# Altium schematic sheet Peripherals.SchDoc(Peripherals)
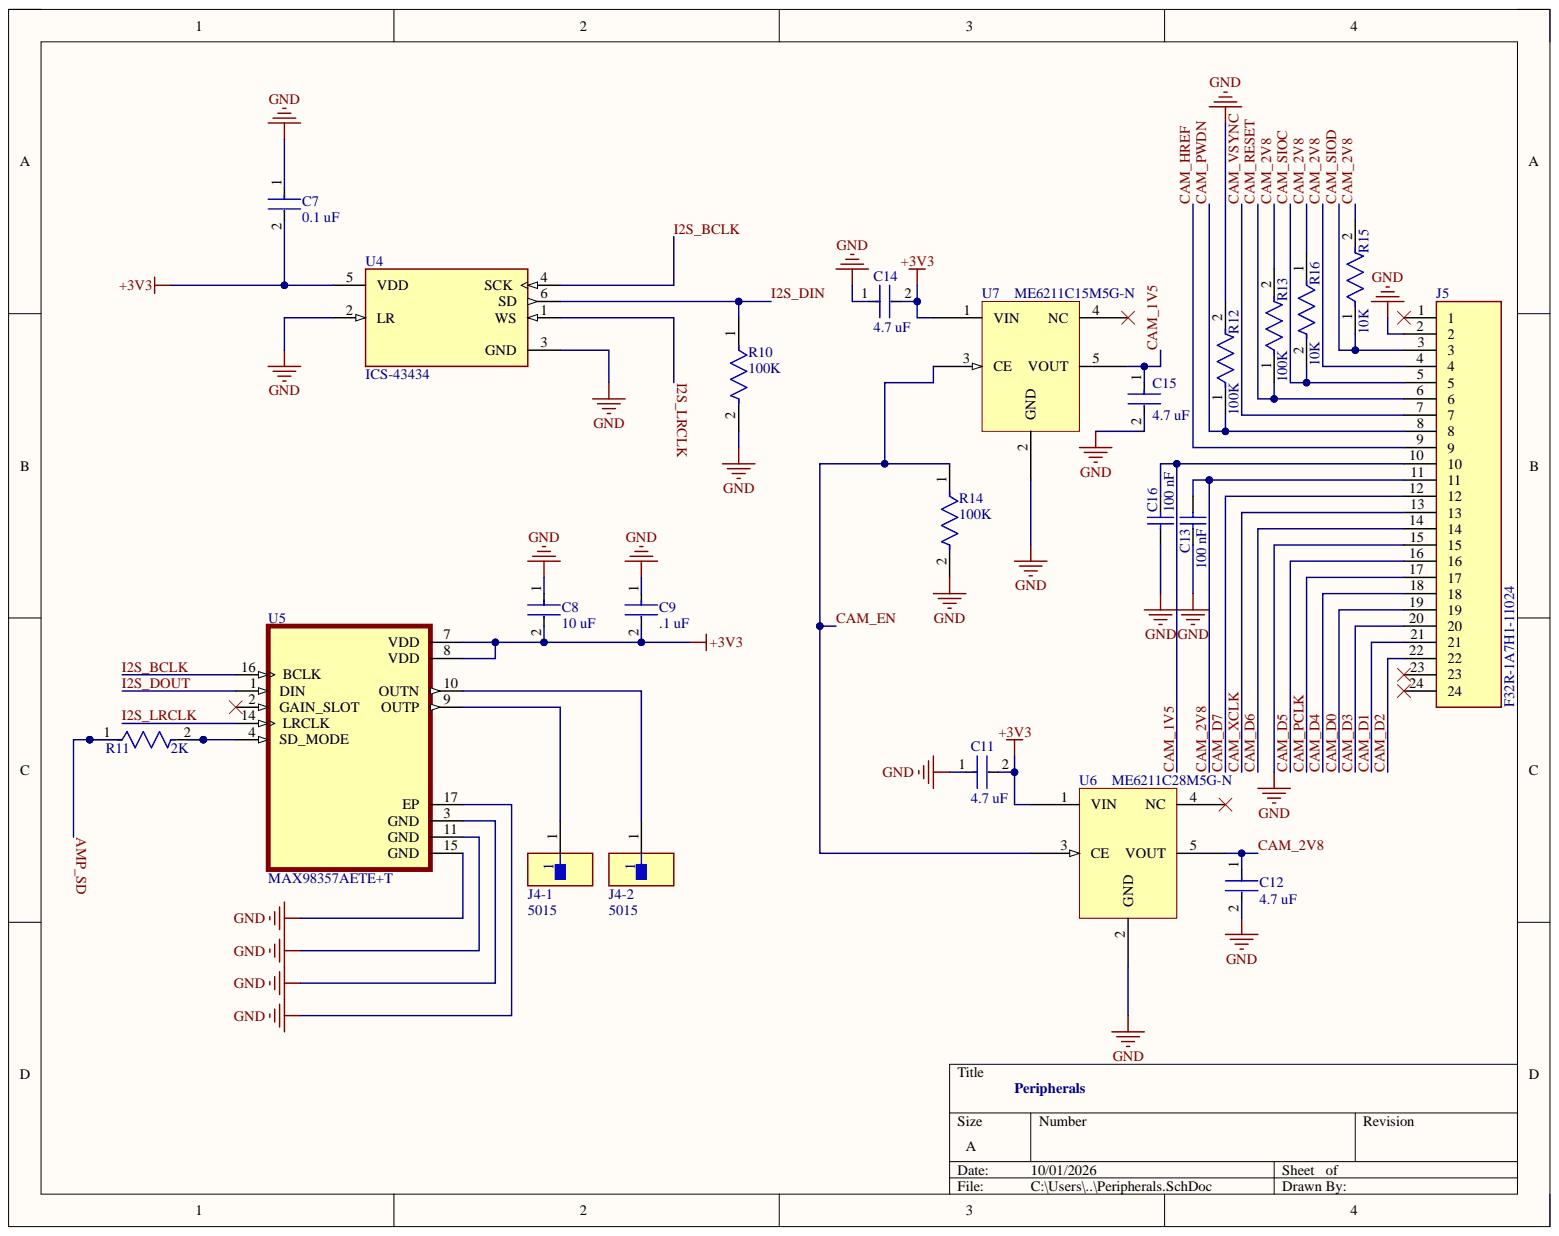
[diagram p0: Peripherals.SchDoc]

## Parts
C7  0.1 uF  [FP-GRM155-0_05-MFG]
C8  10 uF  [FP-C0805C106K4PACTU-MFG]
C9  .1 uF  [FP-C0402-BB-MFG]
C11  4.7 uF  [FP-CC0805-0_85-MFG]
C12  4.7 uF  [FP-CC0805-0_85-MFG]
C13  100 nF  [CAPC0402(1005)60_L]
C14  4.7 uF  [FP-CC0805-0_85-MFG]
C15  4.7 uF  [FP-CC0805-0_85-MFG]
C16  100 nF  [CAPC0402(1005)60_L]
J4-1  5015  [FP-5015-MFG]  (no BOM)
J4-2  5015  [FP-5015-MFG]  (no BOM)
J5  F32R-1A7H1-11024  [AMPHENOL_F32R-1A7H1-11024]
R10  100K  [FP-RT0402-MFG]
R11  2K  [FP-AF0402-IPC_B]
R12  100K  [FP-AC0402-MFG]
R13  100K  [FP-AC0402-MFG]
R14  100K  [FP-AC0402-MFG]
R15  10K  [FP-AC0402-IPC_B]
R16  10K  [FP-AC0402-IPC_B]
U4  ICS-43434  [FP-ICS-43434-MFG]
U5  MAX98357AETE+T  [QFN50P300X300X80-17N]
U6  ME6211C28M5G-N  [SOT95P280X145-5N]
U7  ME6211C15M5G-N  [SOT95P280X145-5N]

## Nets
+3V3: C7.2 C8.2 C9.2 C11.2 C14.2 U4.5(VDD) U5.7(VDD) U5.8(VDD) U6.1(VIN) U7.1(VIN)
GND: C7.1 C8.1 C9.1 C11.1 C12.2 C13.1 C14.1 C15.2 C16.1 J5.2 J5.15 R10.2 R12.2 R14.2 U4.2(LR) U4.3(GND) U5.3(GND) U5.11(GND) U5.15(GND) U5.17(EP) U6.2(GND) U7.2(GND)
AMP_SD: R11.1
CAM_1V5: C15.1 C16.2 J5.10 U7.5(VOUT)
CAM_2V8: C12.1 C13.2 J5.4 J5.11 R13.2 R15.2 R16.1 U6.5(VOUT)
CAM_D0: J5.19
CAM_D1: J5.21
CAM_D2: J5.22
CAM_D3: J5.20
CAM_D4: J5.18
CAM_D5: J5.16
CAM_D6: J5.14
CAM_D7: J5.12
CAM_EN: R14.1 U6.3(CE) U7.3(CE)
CAM_HREF: J5.9
CAM_PCLK: J5.17
CAM_PWDN: J5.8 R12.1
CAM_RESET: J5.6 R13.1
CAM_SIOC: J5.5 R16.2
CAM_SIOD: J5.3 R15.1
CAM_VSYNC: J5.7
CAM_XCLK: J5.13
I2S_BCLK: U4.4(SCK) U5.16(BCLK)
I2S_DIN: R10.1 U4.6(SD)
I2S_DOUT: U5.1(DIN)
I2S_LRCLK: U4.1(WS) U5.14(LRCLK)
NetJ4-1_1: J4-1.1 U5.9(OUTP)
NetJ4-2_1: J4-2.1 U5.10(OUTN)
NetR11_2: R11.2 U5.4(SD_MODE)

Not connected: J5 1 23 24; U5 2(GAIN_SLOT); U6 4(NC); U7 4(NC)

## Library data of the parts
C7 = CMP-06035-062118-1: Chip Multilayer Ceramic Capacitors for General Purpose, 0402, 0.10uF, X7R, 15%, 20%, 50V; Component Kind: Standard; Library Name: Altium Content Vault; RoHS Compliant: Yes
C8 = CMP-03020-034671-1: CAP CER 10UF 16V X5R 0805; Dielectric Material: Ceramic; Dissipation Factor: 5%; Tolerance: 10%; Capacitance: 10uF; Case Code (Imperial): 0805; Case Code (Metric): 2012; Case/Package: 0805; Component Kind: Standard; Construction: Flat; Contact Plating: Tin; Depth: 1.25mm; Dielectric: X5R; Height: 1.25mm; Lead Free: Lead Free; Length: 2mm; Library Name: Altium Content Vault; Max Operating Temperature: 85°C; Min Operating Temperature: -55°C; Mount: Surface Mount; Number of Pins: 2; Package Quantity: 1; Packaging: Tape and Reel; Radiation Hardening: No; REACH SVHC: No SVHC; Series: C; Termination: SMD/SMT; Thickness: 0.055inch; Voltage: 16V; Voltage Rating: 16V; Voltage Rating (DC): 16V; Width: 0.049inch
C9 = CMP-2008-02108-2: CAP CER 0.1UF 16V X7R 0402; Manufacturer: Kemet; Manufacturer Part Number: C0402C104K4RACTU; Capacitance: 0.10 μF; Material: Ceramic; Temperature Coefficient: 15%; Tolerance: 0.1; Value: 0.10 μF; Automotive: No; Category: Cap; Component Kind: Standard; ComponentLink2Description: Datasheet; ComponentLink2URL: https://content.kemet.com/datasheets/KEM_C1002_X7R_SMD.pdf; Device Class L1: Passive Components; Device Class L2: Capacitors; Device Class L3: Ceramic Capacitors; Digikey Description: CAP CER 0.1UF 16V X7R 0402; Height: 0.55mm; Ipc Land Pattern Name: CAPC10050X50; Lead Free: Yes; Library Name: Altium Content Vault; Mouser Description: Cap Ceramic 0.1uF 16V X7R 10% SMD 0402 125?C Paper T/R - Tape and Reel (Alt: C0402C104K4RAC TU); Octopart: https://octopart.com/c0402c104k4ractu-kemet-94158; Package: C0402-BB; RoHS: TRUE; Temperature: -55°C to +125°C; Temperature Characteristic: X7R; Temperature Range High: +125°C; Temperature Range Low: -55°C; Voltage Rating: 16 V
C11 C12 C14 C15 = CMP-03422-001114-1: CAP CER 4.7UF 6.3V X5R 0805; Manufacturer: Yageo; Manufacturer Part Number: CC0805MKX5R5BB475; Material: Ceramic; Tolerance: 0.2; Automotive: No; Capacitance: 4.7 uF; Category: Cap; Component Kind: Standard; ComponentLink2Description: Datasheet; ComponentLink2URL: http://www.yageo.com/documents/recent/UPY-GPHC_Y5V_6.3V-to-50V_8.pdf; Device Class L1: Passive Components; Device Class L2: Capacitors; Device Class L3: Ceramic Capacitors; Digikey Description: CAP CER 4.7UF 6.3V X5R 0805; Footprint Url: http://www.yageo.com/exep/pages/download/literatures/UPY-C_GEN_24.pdf; Height: 0.95mm; Ipc Land Pattern Name: CAPC200125X85; Lead Free: Yes; Library Name: Altium Content Vault; Mouser Description: Multilayer Ceramic Capacitors MLCC - SMD/SMT 4.7uF 6.3V X5R 20%; Octopart: https://octopart.com/cc0805mkx5r5bb475-yageo-11543726; Package: CC0805-0.85; RoHS: TRUE; Temperature: -30°C to +85°C; Temperature Characteristic: X5R; Temperature Range High: +85°C; Temperature Range Low: -30°C; Value: 4.7 uF; Voltage Rating: 6.3 V
C13 C16 = CMP-1034-02073-1: CAP 100nF 25V ±20% 0402 (1005 Metric) Thickness 0.6mm SMD; Case-EIA: 0402; Case-Metric: 1005; Tolerance: Â±20%; Component Kind: Standard; Library Name: Altium Content Vault; Max Thickness: 0.6 mm; Rated Voltage: 25 V; Technology: SMT; Value: 100nF
J4-1 J4-2 = CMP-2000-05183-2: Speaker solder pads; Manufacturer: keystone; Conn Orientation: Straight; Number Of Contacts: 1; Automotive: No; Category: Conn; ComponentLink2Description: Datasheet; ComponentLink2URL: http://www.keyelco.com/product-pdf.cfm?p=1353; Contact Material: Silver; Device Class L1: Connectors; Device Class L2: unset; Device Class L3: unset; Digikey Description: PC TEST POINT MINIATURE; Height: 1.65mm; Lead Free: Yes; Library Name: Altium Content Vault; Manufacturer Part Number: 5015; Octopart: https://octopart.com/5015-keystone-3822; Package: 5015; RoHS: TRUE
J5 = CMP-002-00072-1: Flex Connector, 0.50mm Pitch, Height 2.00mm, Right angle, Slider type, ZIF, Bottom contact, 24 position , Without MYLAR; MF: Amphenol ICC / Aorora; Case/Package: None; Check_prices: https://www.snapeda.com/parts/F32R-1A7H1-11024/Amphenol/view-part/?ref=eda; Component Kind: Standard; Component Type: Standard; Design Item ID: F32R-1A7H1-11024; MP: F32R-1A7H1-11024; Pins: 24; SnapEDA_Link: https://www.snapeda.com/parts/F32R-1A7H1-11024/Amphenol/view-part/?ref=snap; Source: F32R-1A7H1-11024.SchLib; Library Name: AI-Glasses
R10 = CMP-03412-032884-1: RES SMD 100KOHM 0.25% 1/16W 0402; Manufacturer: Yageo; Manufacturer Part Number: RT0402CRD07100KL; Power Rating: 0.063W; Resistance: 100KΩ; Temperature Coefficient: 25 ppm/°C; Tolerance: 0.0025; Value: 100KΩ; Voltage Rating: 50V; Automotive: No; Category: Res; Component Kind: Standard; ComponentLink2Description: Datasheet; ComponentLink2URL: http://www.yageo.com/documents/recent/PYu-RT_1-to-0.01_RoHS_L_9.pdf; Composition: Thin Film; Device Class L1: Passive Components; Device Class L2: Resistors; Device Class L3: Chip SMD Resistors; Digikey Description: RES SMD 100KOHM 0.25% 1/16W 0402; Footprint Url: http://www.yageo.com/exep/pages/download/literatures/PYu-R_Mount_10.pdf; Height: 0.35mm; Ipc Land Pattern Name: RESC10050X30; Lead Free: Yes; Library Name: Altium Content Vault; Octopart: https://octopart.com/rt0402crd07100kl-yageo-1246608; Package: RT0402; RoHS: TRUE; Series: RT; Temperature: -55°C to +155°C; Temperature Range High: +155°C; Temperature Range Low: -55°C
R11 = CMP-03412-043195-1: Anti-Sulfurated Chip Resistors Automotive Grade 2kΩ 1% 100ppm/°C 0402; Max Power Dissipation: 63mW; Resistance: 2kR; Case/Package: 0402; Component Kind: Standard; Features: Moisture Resistant; Height: 0.015inch; Length: 0.039inch; Library Name: Altium Content Vault; Max Operating Temperature: 155°C; Min Operating Temperature: -55°C; Number of Terminations: 2; Packaging: Tape and Reel; Resistor Type: Thick Film; RoHS Compliant: Yes; Series: AF; Temperature Coefficient: 100ppm/°C; Tolerance: 1%; Width: 0.02inch
R12-R14 = CMP-03412-035800-2: RES 100kΩ ±1% 0.063W 0402; Component Kind: Standard; Library Name: Altium Content Vault; RoHS Compliant: Yes
R15 R16 = CMP-03412-022979-2: RES 10kΩ ±1% 0.063W 0402; Resistance: 10kR; Case/Package: 0402; Component Kind: Standard; Library Name: Altium Content Vault; RoHS Compliant: Yes; Tolerance: 1%
U4 = CMP-03292-71346757-1: ICS-43434 Mic Omni-Directional -26dB 3.63V Rectangular Solder Pad T/R; Direction: Omnidirectional; Min Supply Voltage: 1.65V; Operating Supply Current: 550µA; Output Type: Digital; Component Kind: Standard; Height: 980µm; Length: 3.5mm; Library Name: Altium Content Vault; Lifecycle Status: Production; Max Length: 3.6mm; Max Operating Temperature: 85°C; Max Supply Voltage: 3.63V; Max Thickness: 1.08mm; Max Width: 2.75mm; Min Length: 3.4mm; Min Operating Temperature: -40°C; Min Thickness: 880µm; Min Width: 2.55mm; NexarPartId: 71346757; RoHS: Compliant; Schedule B: 8518100000; Width: 2.65mm
U5 = CMP-014-00002-1: Tiny, Low-Cost, PCM Class D Amplifier with Class AB Performance; MF: Analog Devices; Case/Package: TQFN-16 Maxim; Check_prices: https://www.snapeda.com/parts/MAX98357AETE+T/Analog+Devices/view-part/?ref=eda; Component Kind: Standard; Component Type: Standard; Design Item ID: MAX98357AETE+T; MP: MAX98357AETE+T; Pins: 13; SnapEDA_Link: https://www.snapeda.com/parts/MAX98357AETE+T/Analog+Devices/view-part/?ref=snap; Source: MAX98357AETE+T.SchLib; Library Name: AI-Glasses
U6 = ME6211C28M5G-N: Component Kind: Standard; Library Name: AiGlasses.SchLib
U7 = ME6211C15M5G-N: Component Kind: Standard; Library Name: AiGlasses.SchLib
